# Altium schematic sheet rotary_schematic.SchDoc(rotary_schematic)
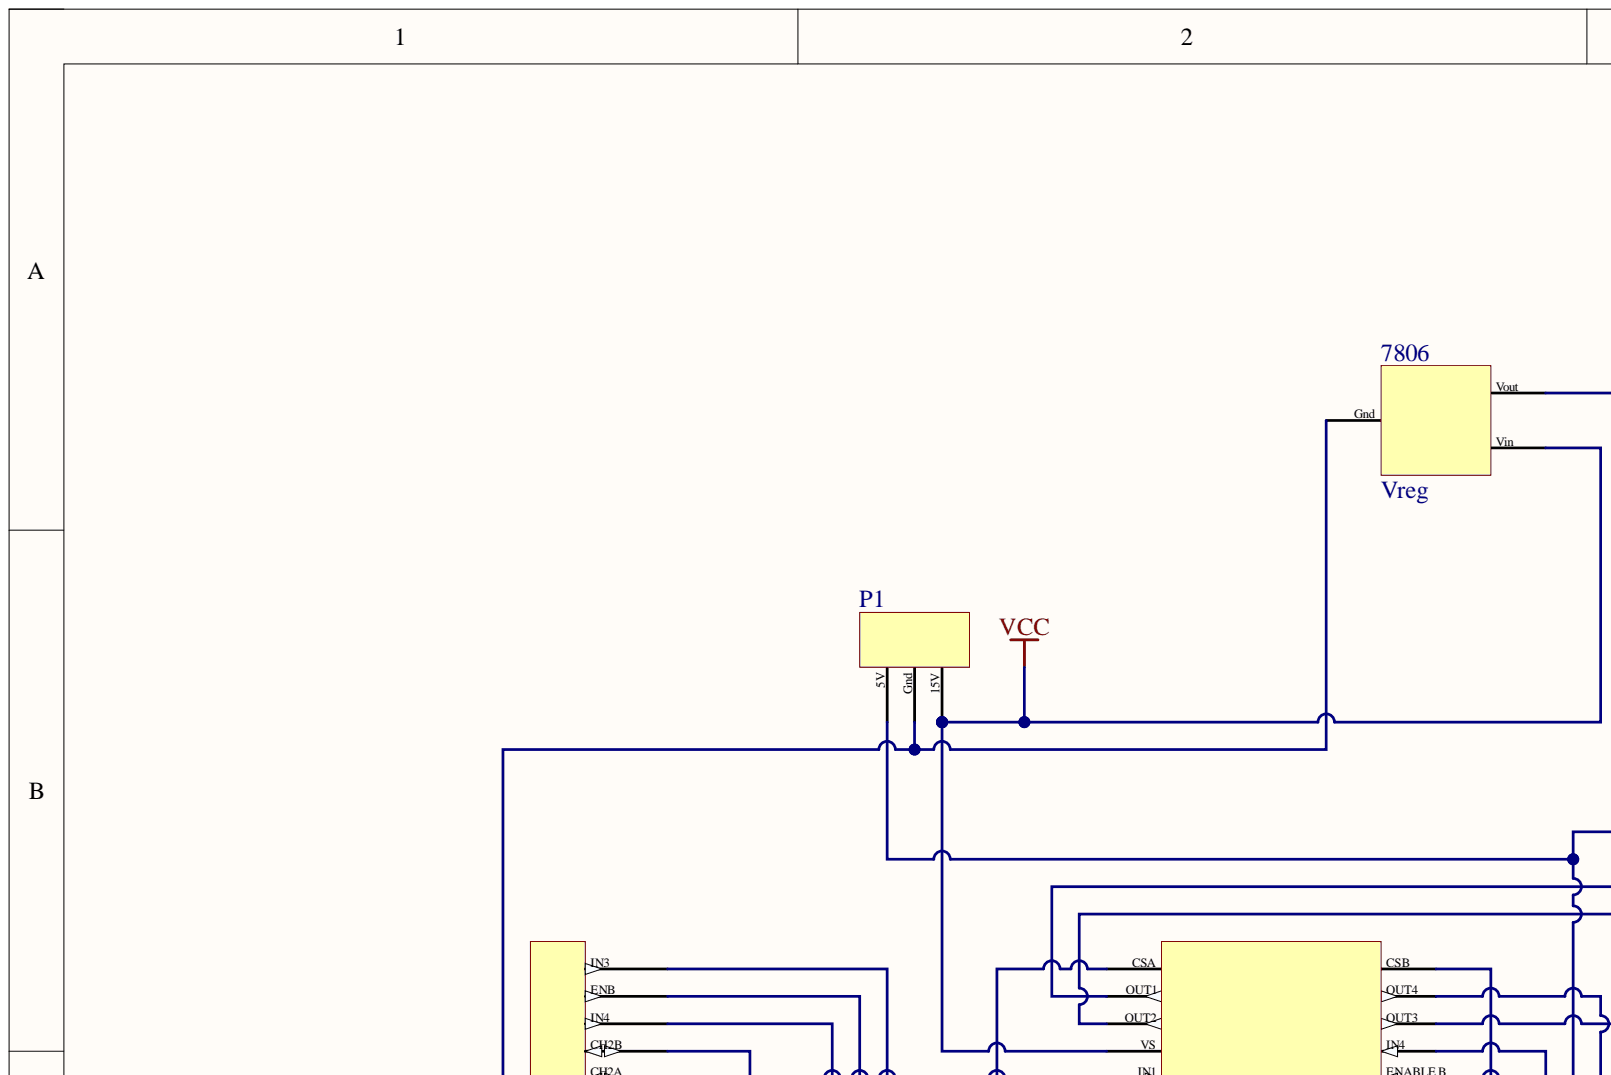
[diagram p0: rotary_schematic.SchDoc, top left]
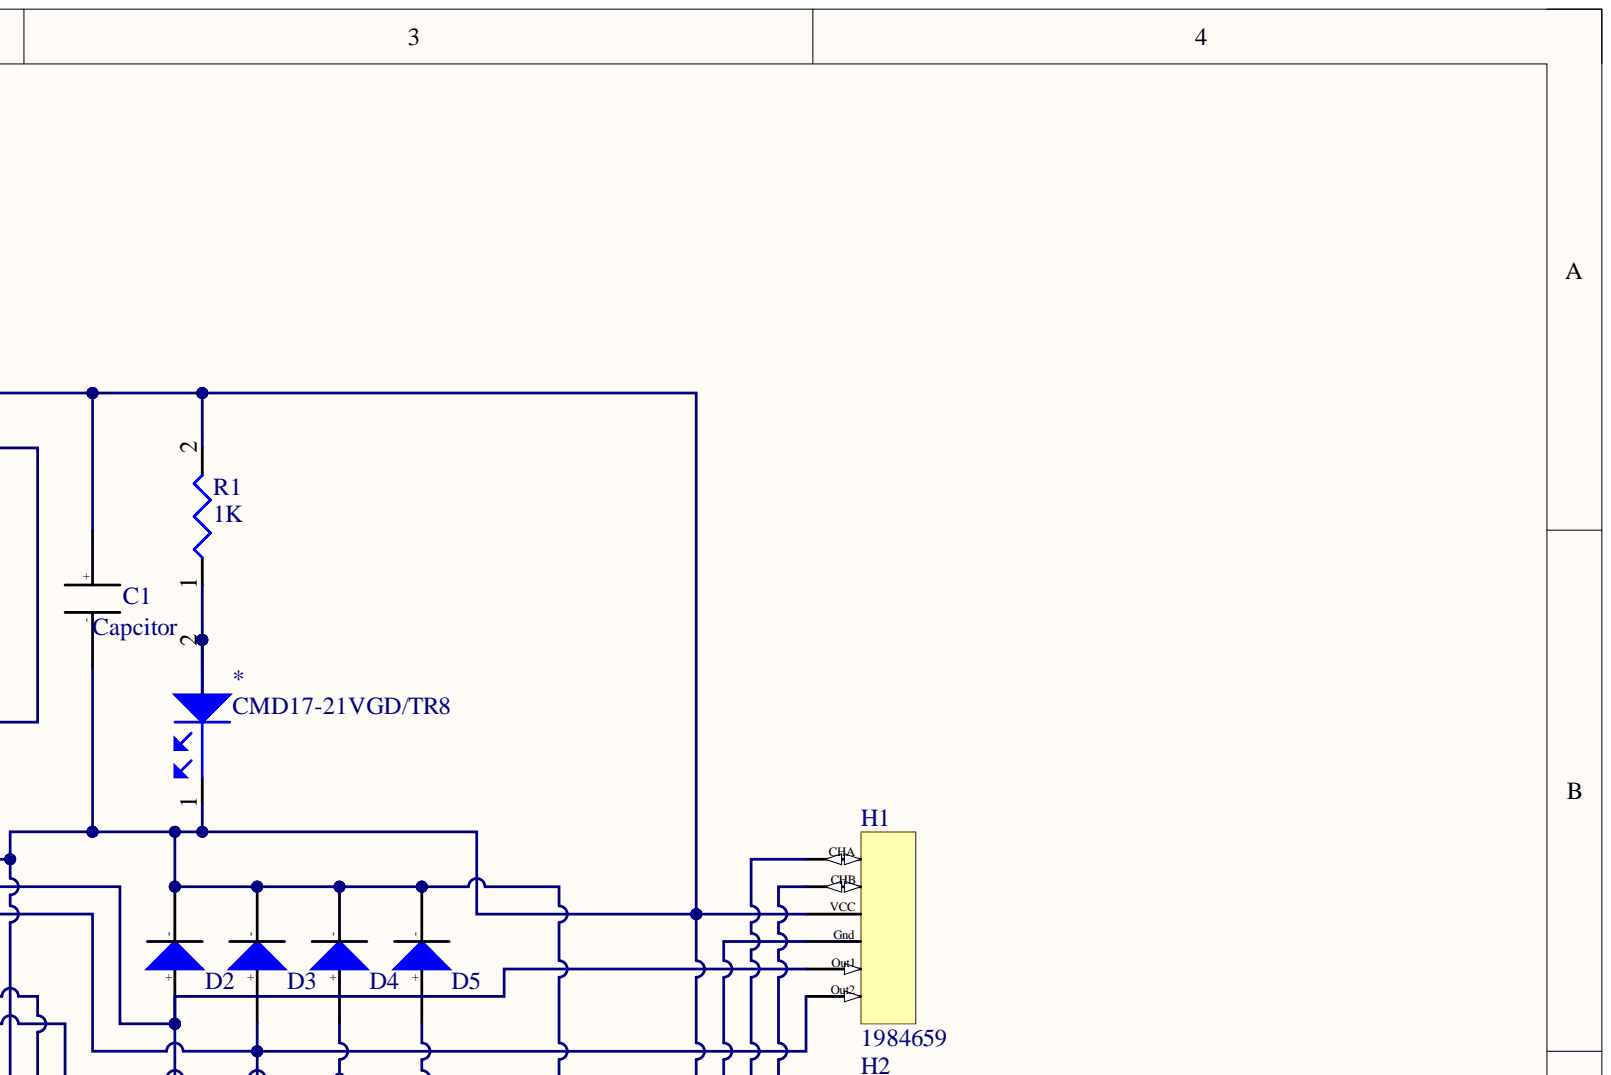
[diagram p1: rotary_schematic.SchDoc, top right]
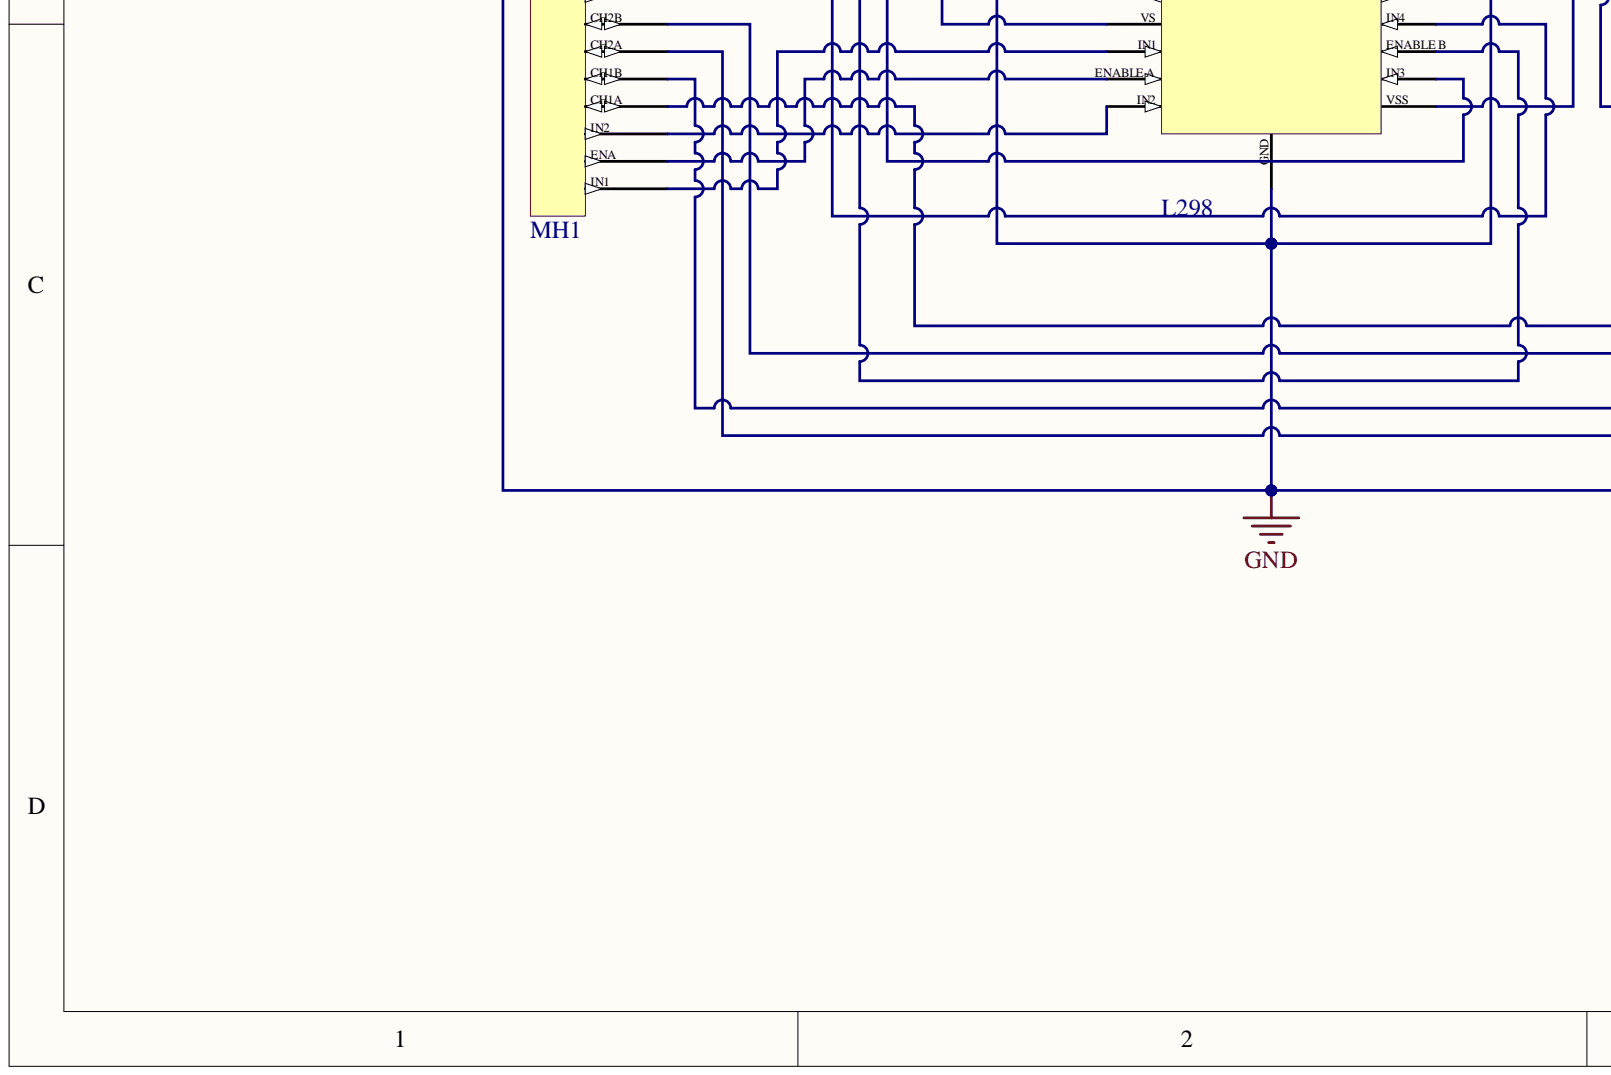
[diagram p2: rotary_schematic.SchDoc, bottom left]
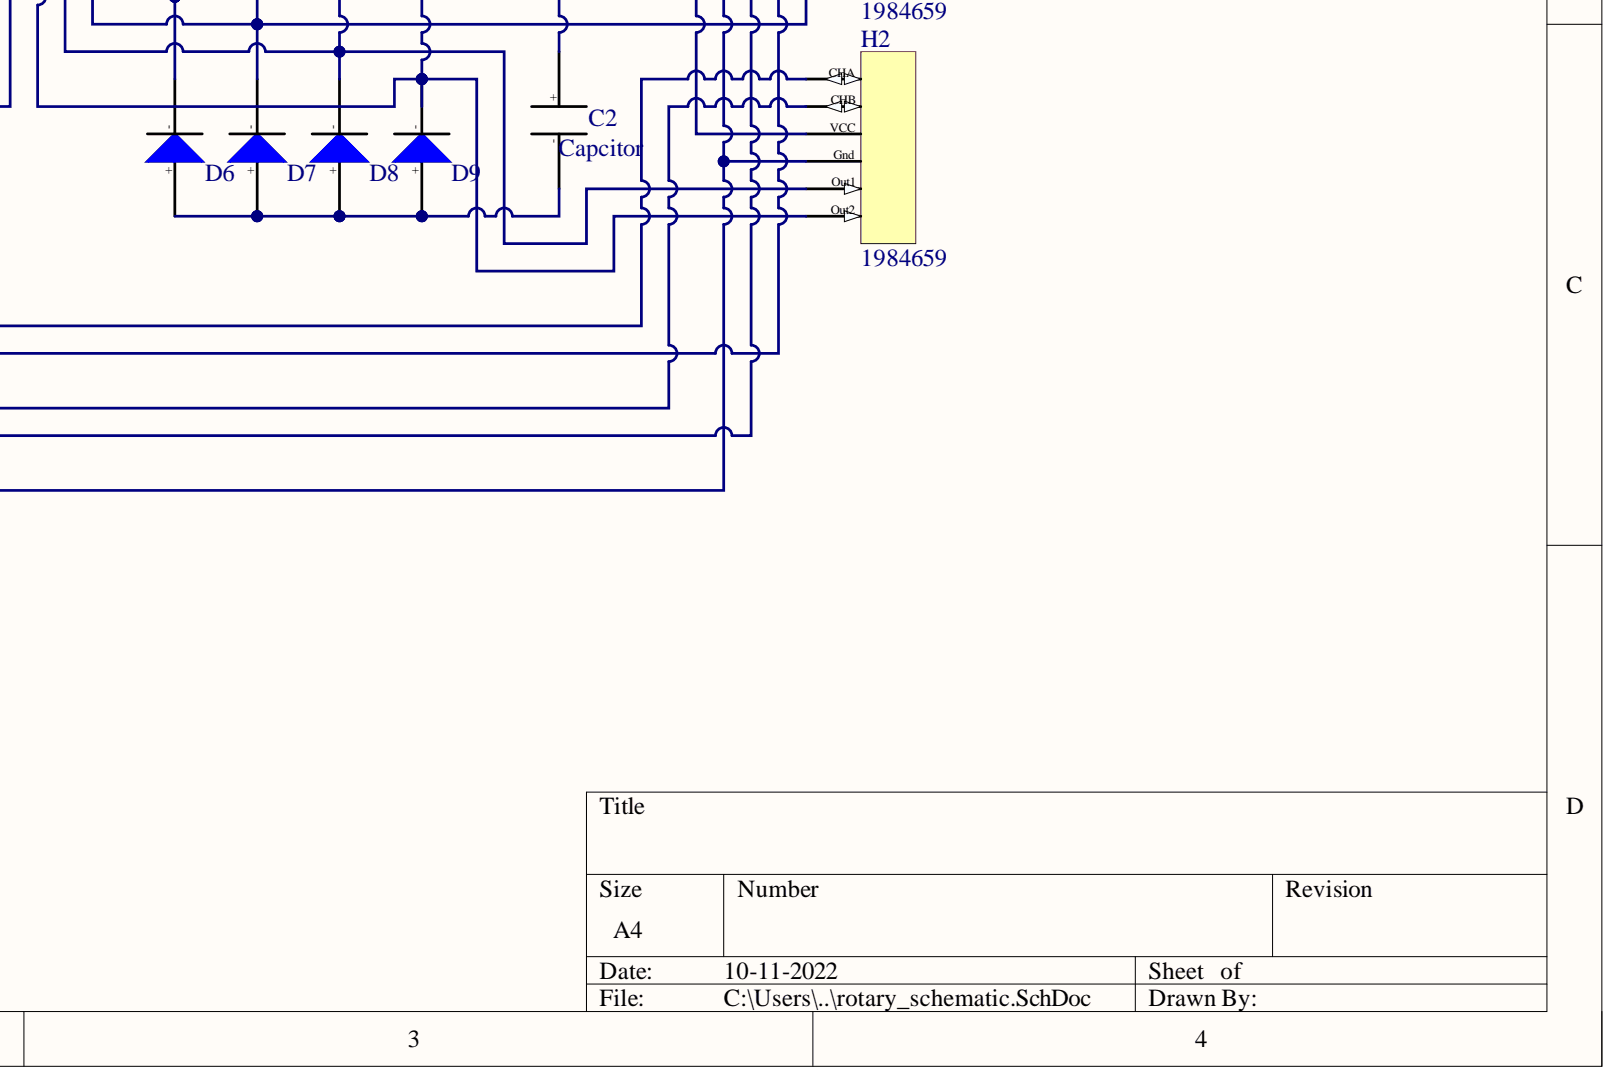
[diagram p3: rotary_schematic.SchDoc, bottom right]

## Parts
7806  Vreg  [VREG_L7805ACD2T]
*  CMD17-21VGD/TR8  [LED_CMD17-21VGD_TR8]
C1  Capcitor  [CAP_EEEFN1V221V]
C2  Capcitor  [CAP_EEEFN1V221V]
D2  US1D-TP  [DIOM4325X250N]  (value not drawn)
D3  US1D-TP  [DIOM4325X250N]  (value not drawn)
D4  US1D-TP  [DIOM4325X250N]  (value not drawn)
D5  US1D-TP  [DIOM4325X250N]  (value not drawn)
D6  US1D-TP  [DIOM4325X250N]  (value not drawn)
D7  US1D-TP  [DIOM4325X250N]  (value not drawn)
D8  US1D-TP  [DIOM4325X250N]  (value not drawn)
D9  US1D-TP  [DIOM4325X250N]  (value not drawn)
H1  1984659  [6pin header]
H2  1984659  [6pin header]
L298  L298N  [L298N]  (value not drawn)
MH1  67997-410HLF  [10pin male header]  (value not drawn)
P1  0393900103  [power connector]  (value not drawn)
R1  1K  [RESC2012X60N]

## Nets
GND: 7806.Gnd H1.Gnd H2.Gnd L298.CSA L298.CSB L298.GND P1.Gnd
VCC: 7806.Vin L298.VS P1.15V
Net7806_Vout: 7806.Vout *.1 C1.+ C1.- C2.+ D2.- D3.- D4.- D5.- H1.VCC H2.VCC L298.VSS P1.5V R1.2
Net*_2: *.2 R1.1
NetC2_-: C2.- D6.+ D7.+ D8.+ D9.+
NetD2_+: D2.+ D6.- H1.Out1 L298.OUT1
NetD3_+: D3.+ D7.- H1.Out2 L298.OUT2
NetD4_+: D4.+ D8.- H2.Out1 L298.OUT3
NetD5_+: D5.+ D9.- H2.Out2 L298.OUT4
NetH1_CHA: H1.CHA MH1.CH2A
NetH1_CHB: H1.CHB MH1.CH2B
NetH2_CHA: H2.CHA MH1.CH1A
NetH2_CHB: H2.CHB MH1.CH1B
NetL298_ENABLE A: L298.ENABLE A MH1.ENA
NetL298_ENABLE B: L298.ENABLE B MH1.ENB
NetL298_IN1: L298.IN1 MH1.IN1
NetL298_IN2: L298.IN2 MH1.IN2
NetL298_IN3: L298.IN3 MH1.IN3
NetL298_IN4: L298.IN4 MH1.IN4

## Library data of the parts
7806 = L7805ACD2T-TR: Linear Voltage Regulator IC Positive Fixed 1 Output 5V 1.5A D2PAK; Manufacturer: STMicroelectronics; Accuracy: 2%; Dropout Voltage: 2V; Max Input Voltage: 35V; Min Input Voltage: 7V; Number of Outputs: 1; Power Supply Rejection Ratio (PSRR): 68dB; Quiescent Current: 6mA; Case/Package: TO-263; Category: Voltage Regulators - Linear; Component Kind: Standard; ComponentLink1Description: https://datasheet.ciiva.com/15111/getdatasheetpartid-69832-15111359.pdf?src-supplier=Verical; ComponentLink1URL: https://datasheet.ciiva.com/15111/getdatasheetpartid-69832-15111359.pdf?src-supplier=Verical; ComponentLink2Description: https://datasheet.ciiva.com/1511/1805459-1511908.pdf?src-supplier=Element14; ComponentLink2URL: https://datasheet.ciiva.com/1511/1805459-1511908.pdf?src-supplier=Element14; ComponentLink3Description: https://datasheet.ciiva.com/2042/cd00000444-2042978.pdf?src-supplier=Digi-Key; ComponentLink3URL: https://datasheet.ciiva.com/2042/cd00000444-2042978.pdf?src-supplier=Digi-Key; ComponentLink4Description: https://datasheet.ciiva.com/2042/cd00000444-2042977.pdf?src-supplier=Digi-Key; ComponentLink4URL: https://datasheet.ciiva.com/2042/cd00000444-2042977.pdf?src-supplier=Digi-Key; ComponentLink5Description: https://datasheet.ciiva.com/2042/cd00000444-2042979.pdf?src-supplier=Digi-Key; ComponentLink5URL: https://datasheet.ciiva.com/2042/cd00000444-2042979.pdf?src-supplier=Digi-Key; ComponentLink6Description: https://datasheet.ciiva.com/16353/cd00000444-16353997.pdf?src-supplier=Future+Electronics; ComponentLink6URL: https://datasheet.ciiva.com/16353/cd00000444-16353997.pdf?src-supplier=Future+Electronics; Contact Plating: Tin,Matte; Height: 4.6mm; Lead Free: Lead Free; Length: 10.4mm; Manufacturer Part Number: L7805ACD2T-TR; Max Operating Temperature: 150°C; Max Output Current: 1.5A; Min Operating Temperature: 0°C; Min Output Voltage: 5V; Mount: Surface Mount; Nominal Output Voltage: 5V; Number of Pins: 3; Number of Regulators: 1; Output Current: 1.5A; Output Type: Fixed; Output Voltage: 5V; Output Voltage Accuracy: 2%; Package Quantity: 1000; Packaging: Cut Tape; Polarity: Positive; Pricing 1: 1=1.08000, 10=0.97000, 25=0.92040, 100=0.75600, 250=0.70672, 500=0.62454 (USD); Radiation Hardening: No; REACH SVHC: No SVHC; RoHS: Yes; RoHS Compliant: Yes; Series: L78; Stock 1: 9294; Supplier 1: Digi-Key; Supplier Part Number 1: 497-7253-1-ND; Weight: 7.1E-05oz; Width: 9.35mm; Library Name: rptary_sche_library.SchLib
* = CMD17-21VGD/TR8: LED, Green, SMD 0805, 7.0 mcd, Green Diffused Lens; Manufacturer: VCC CHML; Forward Current: 20mA; Forward Voltage: 2.1V; Lens Style: Rectangular,Diffused,Tinted,Colored; Luminous Intensity: 7mcd; Number of Elements: 1; Power Dissipation: 105mW; Reverse Voltage: 5V; Viewing Angle: 140°; Wavelength: 570nm; Case Code (Imperial): 0805; Case Code (Metric): 2012; Case/Package: 0805; Category: LEDs; Color: Green; Component Kind: Standard; ComponentLink1Description: https://datasheet.ciiva.com/46391/719069-46391062.pdf?src-supplier=Element14; ComponentLink1URL: https://datasheet.ciiva.com/46391/719069-46391062.pdf?src-supplier=Element14; ComponentLink2Description: https://datasheet.ciiva.com/16437/cmd17-21seriessmtleds0805packagesize-16437018.pdf?src-supplier=Future+Electronics; ComponentLink2URL: https://datasheet.ciiva.com/16437/cmd17-21seriessmtleds0805packagesize-16437018.pdf?src-supplier=Future+Electronics; Depth: 1.25mm; Height: 1.1mm; Illumination Color: Green; Lead Free: Lead Free; Length: 2mm; Manufacturer Part Number: CMD17-21VGD/TR8; Max Operating Temperature: 85°C; Min Operating Temperature: -40°C; Mount: Surface Mount; Number of LEDs: 1; Number of Pins: 2; Package Quantity: 3000; Packaging: Tape and Reel; Power Rating: 105mW; Pricing 1: 1=0.71000, 10=0.46900, 100=0.31000, 1000=0.23937 (USD); REACH SVHC: Unknown; Reverse Voltage (DC): 5V; RoHS: Yes; Stock 1: 17236; Supplier 1: Digi-Key; Supplier Part Number 1: L62505CT-ND; Test Current: 20mA; Width: 1.25mm; Library Name: rptary_sche_library.SchLib
C1 C2 = Capcitor: Cap Aluminum 220uF 35V 20% Cylindrical 0.16 Ohm 600mA 2000 hr 105°C Emboss T/R; Manufacturer: Panasonic; Manufacturer Part Number: EEE-FN1V221V; Category: Aluminum Electrolytic Capacitors; Component Kind: Standard; Pricing 1: 1=1.49000, 10=1.17400, 50=1.01720, 100=0.88030 (USD); RoHS: No; Stock 1: 400; Supplier 1: Digi-Key; Supplier Part Number 1: 10-EEE-FN1V221VCT-ND; Library Name: rptary_sche_library.SchLib
D2-D9 = US1D-TP: Rectifier Diode Switching 200V 1A 50ns 2-Pin SMA T/R; Manufacturer: MCC; Element Configuration: Single; Max Forward Surge Current (Ifsm): 30A; Max Reverse Current: 10uA; Average Rectified Current: 1A; Case/Package: DO-214AC; Category: Rectifier Diodes; Component Kind: Standard; ComponentLink1Description: https://datasheet.ciiva.com/917/us1a-us1mdo-214ac-917080.pdf?src-supplier=Digi-Key; ComponentLink1URL: https://datasheet.ciiva.com/917/us1a-us1mdo-214ac-917080.pdf?src-supplier=Digi-Key; ComponentLink2Description: https://datasheet.ciiva.com/917/us1a-us1mdo-214ac-917081.pdf?src-supplier=Digi-Key; ComponentLink2URL: https://datasheet.ciiva.com/917/us1a-us1mdo-214ac-917081.pdf?src-supplier=Digi-Key; ComponentLink3Description: https://datasheet.ciiva.com/917/us1a-us1mdo-214ac-917082.pdf?src-supplier=Digi-Key; ComponentLink3URL: https://datasheet.ciiva.com/917/us1a-us1mdo-214ac-917082.pdf?src-supplier=Digi-Key; ComponentLink4Description: https://datasheet.ciiva.com/14559/70055799-14559382.pdf?src-supplier=Allied+Electronics; ComponentLink4URL: https://datasheet.ciiva.com/14559/70055799-14559382.pdf?src-supplier=Allied+Electronics; Forward Current: 1A; Height: 2.24mm; Length: 4.6mm; Manufacturer Part Number: US1D-TP; Max Operating Temperature: 150°C; Max Repetitive Reverse Voltage (Vrrm): 200V; Max Reverse Voltage (DC): 200V; Max Surge Current: 30A; Min Operating Temperature: -65°C; Mount: Surface Mount; Number of Pins: 2; Package Quantity: 1; Packaging: Tape and Reel; Pricing 1: 1=0.39000, 10=0.29400, 100=0.18300, 500=0.12520, 1000=0.09630, 2000=0.08667 (USD); Recovery Time: 50ns; Reverse Recovery Time: 50ns; Reverse Voltage: 200V; RoHS: Yes; RoHS Compliant: Yes; Series: -; Stock 1: 74856; Supplier 1: Digi-Key; Supplier Part Number 1: US1D-TPMSCT-ND; Width: 2.92mm; Library Name: rptary_sche_library.SchLib
H1 H2 = 1984659: TERM BLK 6POS SIDE ENT 3.5MM PCB; Manufacturer: Phoenix Contact; Approvals: UL,EAC; Current: 10A; Current Rating: 17.5A; Gender: Male; Max Supply Voltage: 160V; Number of Circuits: 6; Number of Levels: 1; Stripping Length: 5mm; Voltage: 300V; Wire Gauge (Max): 26AWG; Category: Terminal Blocks; Color: Green; Component Kind: Standard; ComponentLink1Description: https://datasheet.ciiva.com/15197/getdatasheetpartid-686372-15197733.pdf?src-supplier=Verical; ComponentLink1URL: https://datasheet.ciiva.com/15197/getdatasheetpartid-686372-15197733.pdf?src-supplier=Verical; ComponentLink2Description: https://datasheet.ciiva.com/16070/1984659-16070647.pdf?src-supplier=Onlinecomponents.com; ComponentLink2URL: https://datasheet.ciiva.com/16070/1984659-16070647.pdf?src-supplier=Onlinecomponents.com; ComponentLink3Description: https://datasheet.ciiva.com/14608/70055019-14608229.pdf?src-supplier=Allied+Electronics; ComponentLink3URL: https://datasheet.ciiva.com/14608/70055019-14608229.pdf?src-supplier=Allied+Electronics; ComponentLink4Description: https://datasheet.ciiva.com/824/combiconcompactseries-824281.pdf?src-supplier=Digi-Key; ComponentLink4URL: https://datasheet.ciiva.com/824/combiconcompactseries-824281.pdf?src-supplier=Digi-Key; Contact Material: Tin; Depth: 7.6mm; Flammability Rating: UL94 V-0; Height: 9mm; Housing Color: Green; Housing Material: Polyamide; Insulation Material: Nylon; Lead Free: Lead Free; Length: 21mm; Manufacturer Part Number: 1984659; Material: Polyamide; Max Current Rating: 17.5A; Mount: Through Hole; Number of Contacts: 6; Number of Pins: 6; Number of Poles: 6; Number of Positions: 6; Number of Positions Per Level: 6; Number of Rows: 1; Orientation: Straight; Package Quantity: 100; Packaging: Bulk; Pitch: 3.5mm; Pricing 1: 1=1.76000, 10=1.47400, 50=1.43380, 100=1.34000, 250=1.23280, 500=1.19850 (USD); Radiation Hardening: No; RoHS: Yes; RoHS Compliant: Yes; Series: COMBICON PT; Stock 1: 10774; Supplier 1: Digi-Key; Supplier Part Number 1: 277-1724-ND; Termination: Screw; Voltage Rating: 160V; Weight: 0.099825oz; Width: 7.6mm; Wire Gauge (Min): 26AWG; Library Name: rptary_sche_library.SchLib
L298 = L298N: IC BRIDGE DRIVER PAR 15MULTIWATT; Manufacturer: STMicroelectronics; Collector Emitter Voltage (VCEO): 46V; Max Collector Current: 3A; Min Supply Voltage: 4.8V; Motor Type: Brushed; Number of Drivers: 2; Number of Outputs: 4; Operating Supply Current: 13mA; Operating Supply Voltage: 42V; Output Current: 2A; Output Impedance: 24mR; Quiescent Current: 500mA; Category: Motor Drivers; Component Kind: Standard; ComponentLink1Description: https://datasheet.ciiva.com/14150/ofl298n-647108-dat-en-14150301.pdf?src-supplier=Distrelec; ComponentLink1URL: https://datasheet.ciiva.com/14150/ofl298n-647108-dat-en-14150301.pdf?src-supplier=Distrelec; ComponentLink2Description: https://datasheet.ciiva.com/19456/245403-19456698.pdf?src-supplier=Jameco; ComponentLink2URL: https://datasheet.ciiva.com/19456/245403-19456698.pdf?src-supplier=Jameco; ComponentLink3Description: https://datasheet.ciiva.com/25626/0900766b80060c26-25626619.pdf?src-supplier=RSComponents; ComponentLink3URL: https://datasheet.ciiva.com/25626/0900766b80060c26-25626619.pdf?src-supplier=RSComponents; ComponentLink4Description: https://datasheet.ciiva.com/15109/getdatasheetpartid-50682-15109332.pdf?src-supplier=Verical; ComponentLink4URL: https://datasheet.ciiva.com/15109/getdatasheetpartid-50682-15109332.pdf?src-supplier=Verical; ComponentLink5Description: https://datasheet.ciiva.com/29306/156128-da-01-en-dual-bridge-driv-l298n-29306997.pdf?src-supplier=Conrad; ComponentLink5URL: https://datasheet.ciiva.com/29306/156128-da-01-en-dual-bridge-driv-l298n-29306997.pdf?src-supplier=Conrad; ComponentLink6Description: https://datasheet.ciiva.com/1501/1693054-1501547.pdf?src-supplier=Element14; ComponentLink6URL: https://datasheet.ciiva.com/1501/1693054-1501547.pdf?src-supplier=Element14; ComponentLink7Description: https://datasheet.ciiva.com/1608/1693054-1608165.pdf?src-supplier=Newark; ComponentLink7URL: https://datasheet.ciiva.com/1608/1693054-1608165.pdf?src-supplier=Newark; ComponentLink8Description: https://datasheet.ciiva.com/1500/1693054-1500714.pdf?src-supplier=Element14; ComponentLink8URL: https://datasheet.ciiva.com/1500/1693054-1500714.pdf?src-supplier=Element14; ComponentLink9Description: https://datasheet.ciiva.com/1955/cd00000240-1955436.pdf?src-supplier=Digi-Key; ComponentLink9URL: https://datasheet.ciiva.com/1955/cd00000240-1955436.pdf?src-supplier=Digi-Key; ComponentLink10Description: https://datasheet.ciiva.com/4895/cd00000240-4895919.pdf?src-supplier=Mouser; ComponentLink10URL: https://datasheet.ciiva.com/4895/cd00000240-4895919.pdf?src-supplier=Mouser; Height: 10.7mm; Lead Free: Lead Free; Length: 19.6mm; Manufacturer Part Number: L298N; Max Operating Temperature: 130°C; Max Output Current: 3A; Max Supply Voltage: 46V; Min Operating Temperature: -25°C; Mount: Through Hole; Number of Pins: 15; Output Current per Channel: 2A; Output Voltage: 46V; Package Quantity: 25; Packaging: Rail/Tube; Radiation Hardening: No; REACH SVHC: No SVHC; RoHS: Yes; RoHS Compliant: Yes; Series: -; Supplier 1: Digi-Key; Supplier Part Number 1: 497-1395-5-ND; Width: 5mm; Library Name: rptary_sche_library.SchLib
MH1 = 67997-410HLF: CONN HEADER VERT 10POS 2.54MM; Manufacturer: Amphenol Communications Solutions; Category: Connectors; Component Kind: Standard; Manufacturer Part Number: 67997-410HLF; Pricing 1: 1=0.36000, 10=0.30300, 400=0.21153, 800=0.17788, 1200=0.16827, 5200=0.14423, 10000=0.13461 (USD); RoHS: No; Stock 1: 27834; Supplier 1: Digi-Key; Supplier Part Number 1: 609-3243-ND; Library Name: rptary_sche_library.SchLib
P1 = 0393900103: 9.52mm Pitch Beau Eurostyle Fixed Mount PCB Terminal Block, Modular, 3 Circuits; Manufacturer: Molex; Category: Terminal Blocks; Component Kind: Standard; Manufacturer Part Number: 0393900103; Pricing 1: 1=1.72000, 10=1.30300, 25=1.20760, 50=1.08600, 100=1.05940, 250=0.92700, 500=0.84756, 1000=0.76810, 5000=0.67540 (USD); RoHS: Yes; Stock 1: 5467; Supplier 1: Digi-Key; Supplier Part Number 1: WM13946-ND; Library Name: rptary_sche_library.SchLib
R1 = AC0805FR-07360RL: RES SMD 360 OHM 1% 1/8W 0805; Manufacturer: Yageo; Additional Feature: LASER TRIMMABLE; Package Height: 0.5mm; Package Length: 2mm; Package Width: 1.25mm; Rated Power Dissipation (P): 125mW; Rated Temperature: 70°C; Reference Standard: AEC-Q200; Resistance: 360R; Temperature Coefficient: 100ppm/°C; Working Voltage: 150V; Category: Chip SMD Resistors; Component Kind: Standard; ComponentLink1Description: https://datasheet.ciiva.com:443/pdfs/VipMasterIC/IC/YAGO/YAGO-S-A0003597364/YAGO-S-A0003597364-1.pdf?src-supplier=IHS+Markit; ComponentLink1URL: https://datasheet.ciiva.com:443/pdfs/VipMasterIC/IC/YAGO/YAGO-S-A0003597364/YAGO-S-A0003597364-1.pdf?src-supplier=IHS+Markit; ComponentLink2Description: http://components.arrow.com/part/dataview/0323_03350853?src-supplier=Arrow+Europe; ComponentLink2URL: http://components.arrow.com/part/dataview/0323_03350853?src-supplier=Arrow+Europe; ComponentLink3Description: http://www.yageo.com/NewPortal/yageodocoutput?fileName=/pdf/R-Chip/PYu-AC_51_RoHS_L_6.pdf&src-supplier=Digi-Key; ComponentLink3URL: http://www.yageo.com/NewPortal/yageodocoutput?fileName=/pdf/R-Chip/PYu-AC_51_RoHS_L_6.pdf&src-supplier=Digi-Key; Construction: Chip; JESD-609 Code: e3; Manufacturer Part Number: AC0805FR-07360RL; Max Operating Temperature: 155°C; Min Operating Temperature: -55°C; Mount: Surface Mount; Number of Pins: 2; Package Shape: Rectangular; Package Style: SMTMeter; Packaging: Tape and Reel; Pricing 1: 1=0.10000, 10=0.05000, 100=0.02040, 1000=0.00917, 2500=0.00796 (USD); REACH SVHC: Yes; Resistor Type: FIXED RESISTOR; RoHS: Yes; RoHS Compliant: Yes; Size Code: 0805; Stock 1: 10000; Supplier 1: Digi-Key; Supplier Part Number 1: 13-AC0805FR-07360RLCT-ND; Technology: METAL GLAZE/THICK FILM; Terminal Finish: Nickel; Termination: Wraparound; Tolerance: 1%; Library Name: rptary_sche_library.SchLib
